# Altium schematic sheet CANBUS.SchDoc
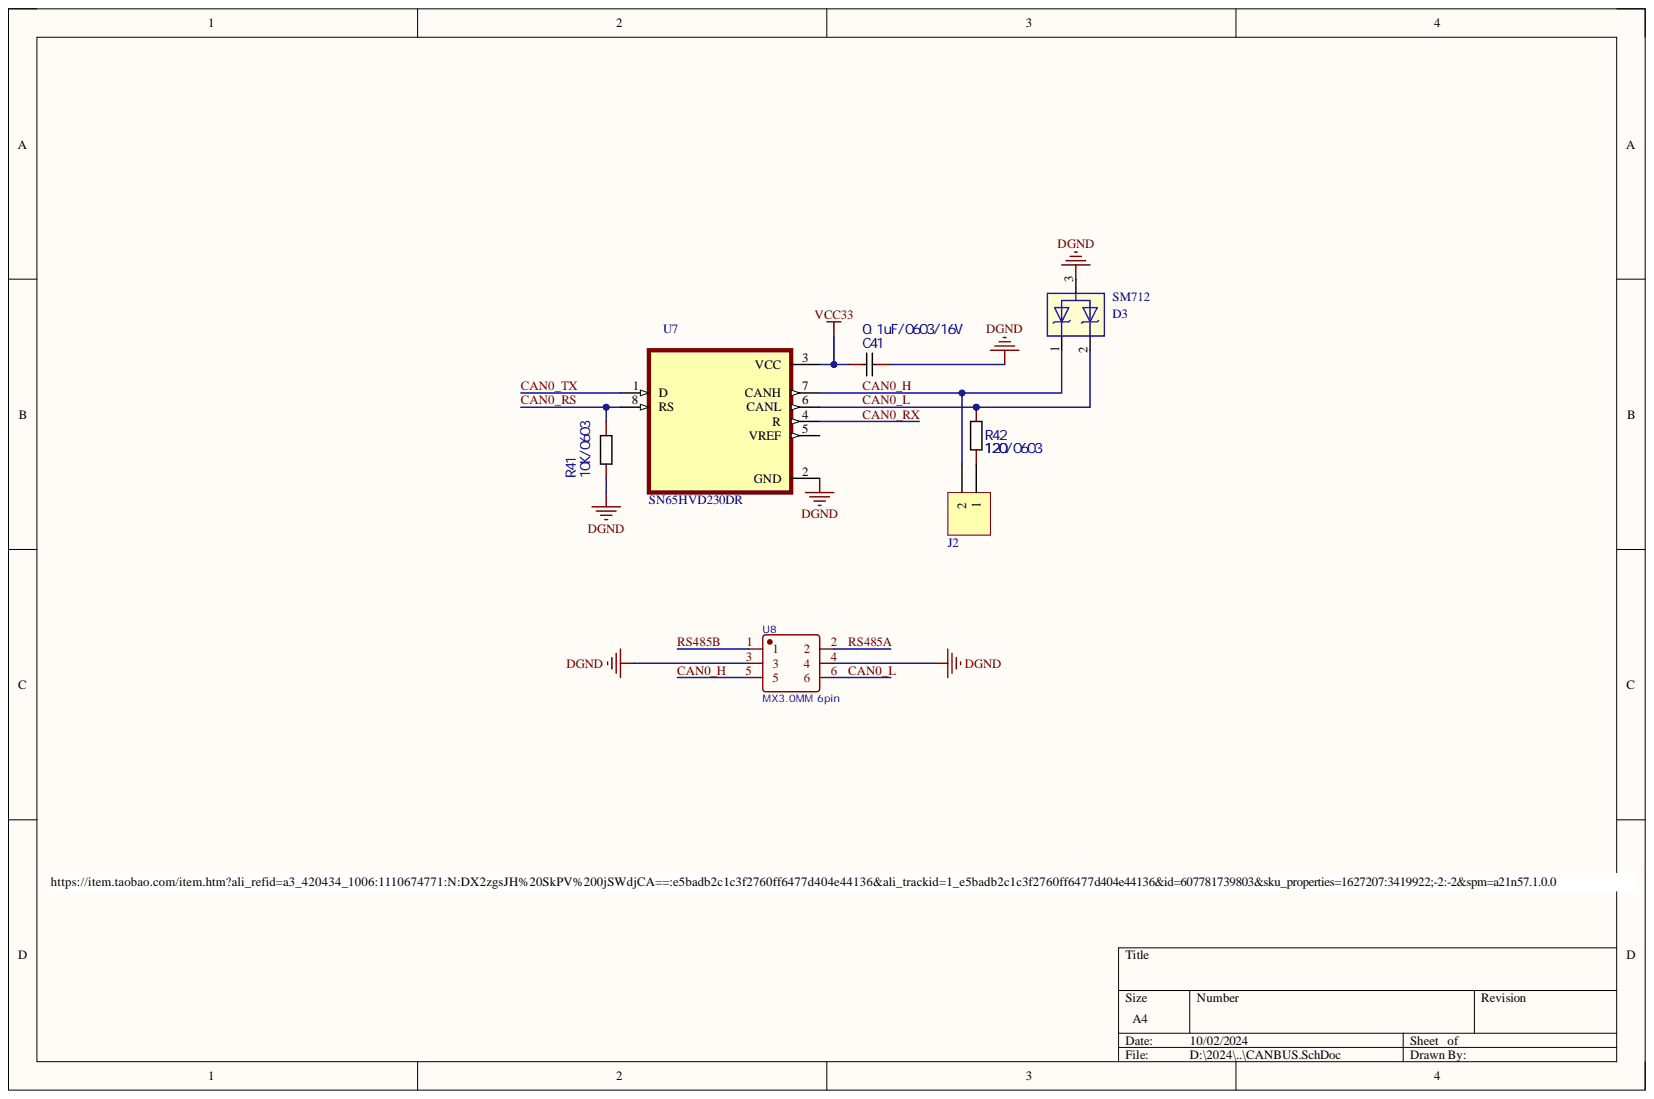
[diagram p0: CANBUS.SchDoc]

## Parts
C41  0.1uF/0603/16V  [CAP0603]
D3  SM712  [SOT95P280X135-3N]
J2  Jumper  [HDR1X2]  (value not drawn)
R41  10K/0603  [RES0603]
R42  120/0603  [RES0603]
U7  SN65HVD230DR  [SN65HVD230DR]
U8  MX3.0MM 6pin  [CONN-TH_6P-P3.00_HC-MX3.0-2X3AW]

## Nets
DGND: C41.1 D3.3 R41.1 U7.2(GND) U8.3 U8.4
VCC33: C41.2 U7.3(VCC)
CAN0_H: D3.1 J2.2 U7.7(CANH) U8.5
CAN0_L: D3.2 R42.1 U7.6(CANL) U8.6
CAN0_RS: R41.2 U7.8(RS)
CAN0_RX: U7.4(R)
CAN0_TX: U7.1(D)
RS485A: U8.2
RS485B: U8.1
NetJ2_1: J2.1 R42.2

Not connected: U7 5(VREF)

## Library data of the parts
C41 = 100nF: Manufacturer: SAMSUNG; Manufacturer Part: CL05B104KO5NNNC; Add into BOM: yes; Origin Footprint: C0402_NEW; Component Kind: Standard; Convert to PCB: yes; Device: 100nF; JLCPCB Part Class: Basic Part; Name: 100nF; Supplier: LCSC; Supplier Part: C1525; Symbol: 100nF
D3 = SM05_D24: Part Number: 702112964; Design: 3; Origin: Altium; Component Kind: Standard; PCB Footprint: SOT95P280X135-3N
J2 = Header 2: Header, 2-Pin; Component Kind: Standard; LatestRevisionDate: 17-Jul-2002; LatestRevisionNote: Re-released for DXP Platform.; Library Name: Miscellaneous Connectors.IntLib; Signal Integrity: Connector; Publisher: Altium Limited
R41 = 10K: Manufacturer: UniOhm; Manufacturer Part: 0402WGF1002TCE; Add into BOM: yes; Origin Footprint: R0402; Component Kind: Standard; Convert to PCB: yes; Device: 10K; JLCPCB Part Class: Basic Part; Name: 10K; Supplier: LCSC; Supplier Part: C25744; Symbol: 10K
R42 = 120: Manufacturer: RALEC; Manufacturer Part: RTT061200FTP; Add into BOM: yes; Origin Footprint: R1206; Component Kind: Standard; Convert to PCB: yes; Device: 120; JLCPCB Part Class: Extended Part; Name: 120; Supplier: LCSC; Supplier Part: C159715; Symbol: 120
U7 = SN65HVD230DR: 3.3 V CAN Transceiver with Standby Mode; MF: Texas Instruments; Availability: In Stock; Check_prices: https://www.snapeda.com/parts/SN65HVD230DR/Texas+Instruments/view-part/?ref=eda; Component Kind: Standard; MP: SN65HVD230DR; Package: SOIC-8 Texas Instruments; Price: None; Purchase-URL: https://pricing.snapeda.com/search/part/SN65HVD230DR/?ref=eda; SnapEDA_Link: https://www.snapeda.com/parts/SN65HVD230DR/Texas+Instruments/view-part/?ref=snap; Library Name: ESPC6IOTALLinOne.SCHLIB
U8 = MX3.0MM双排43025弯脚插座2*3: Component Kind: Standard; Library Name: ESPC6IOTALLinOne.SCHLIB
